# Altium schematic sheet 04_NOTECARD-CONNECTION.SchDoc
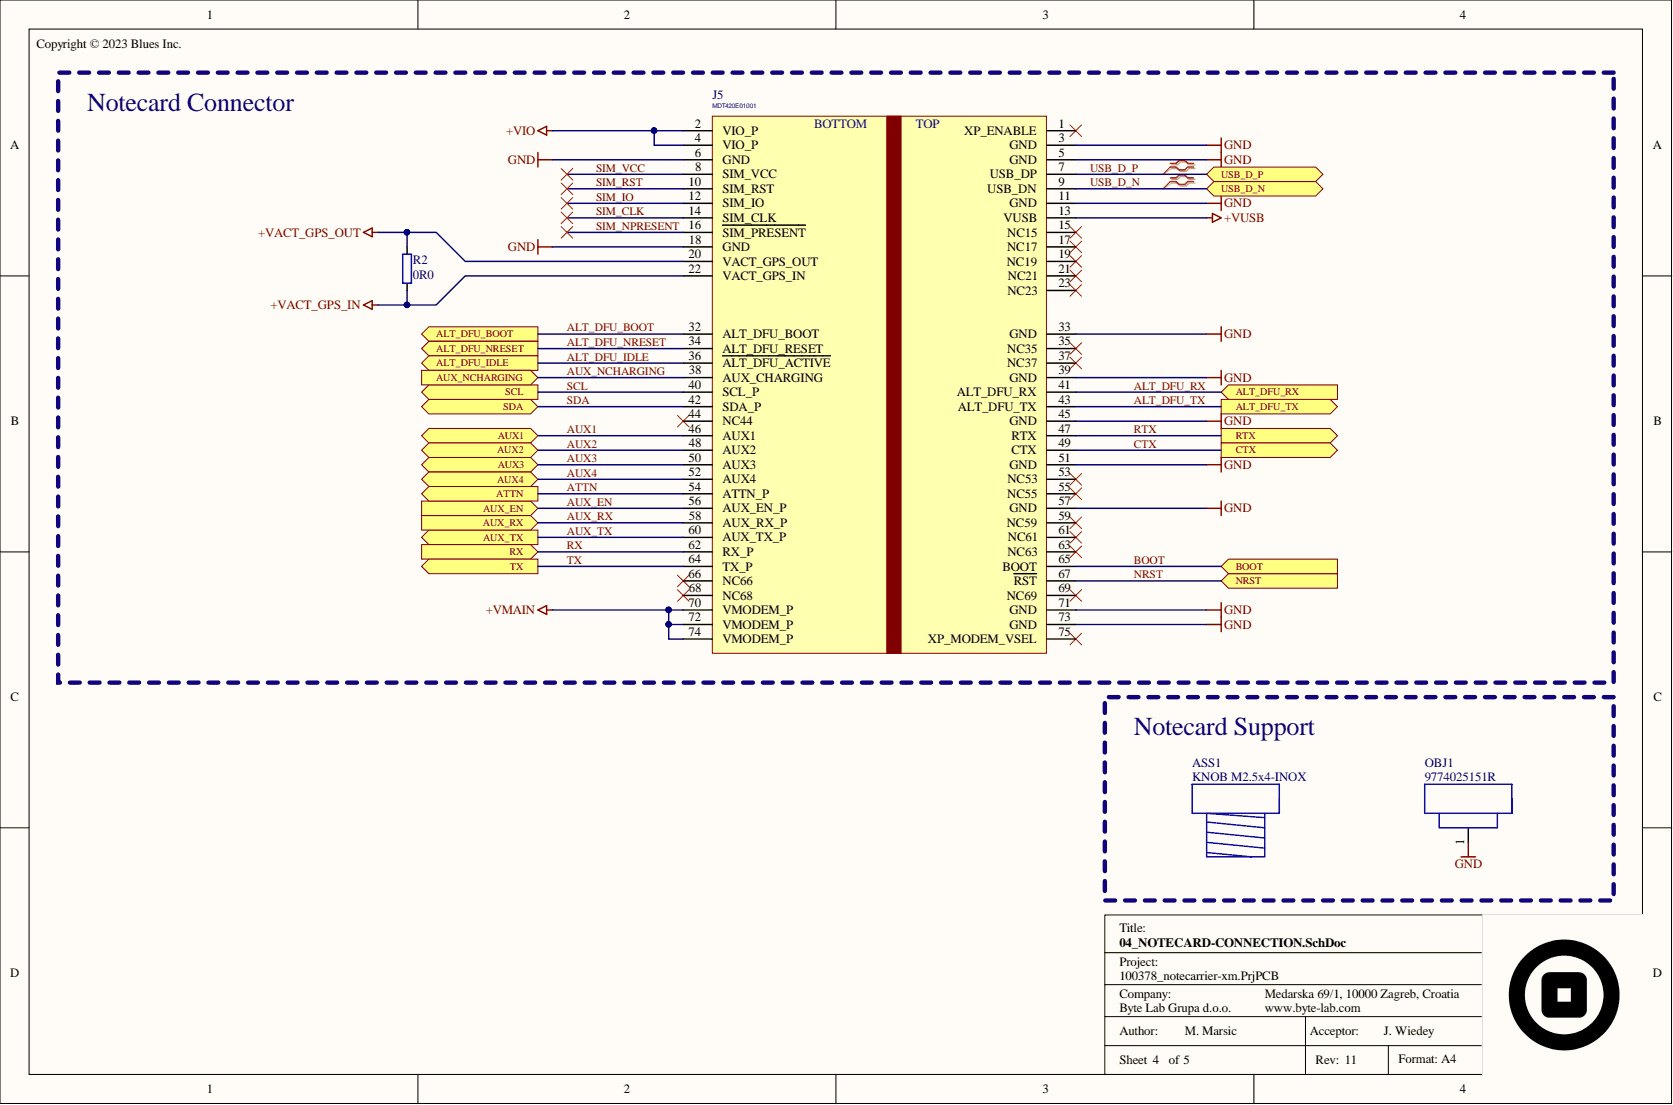
[diagram p0: 04_NOTECARD-CONNECTION.SchDoc]

## Parts
ASS1  KNOB M2.5x4-INOX  (mechanical)
J5  MDT420E01001  [J-75-0050-MOS-M2]
OBJ1  9774025151R  [DIST-WASMSIM0250]
R2  0R0  [R-0603]

## Ports
ALT_DFU_BOOT, ALT_DFU_IDLE, ALT_DFU_NRESET, ALT_DFU_RX, ALT_DFU_TX, ATTN, AUX1, AUX2, AUX3, AUX4, AUX_EN, AUX_NCHARGING, AUX_RX, AUX_TX, BOOT, CTX, NRST, RTX, RX, SCL, SDA, TX, USB_D_N, USB_D_P

## Nets
+VACT_GPS_IN: J5.22(VACT_GPS_IN) R2.1
+VACT_GPS_OUT: J5.20(VACT_GPS_OUT) R2.2
+VIO: J5.2(VIO_P) J5.4(VIO_P)
+VMAIN: J5.70(VMODEM_P) J5.72(VMODEM_P) J5.74(VMODEM_P)
+VUSB: J5.13(VUSB)
GND: J5.3(GND) J5.5(GND) J5.6(GND) J5.11(GND) J5.18(GND) J5.33(GND) J5.39(GND) J5.45(GND) J5.51(GND) J5.57(GND) J5.71(GND) J5.73(GND) OBJ1.1
ALT_DFU_BOOT: J5.32(ALT_DFU_BOOT) ; port ALT_DFU_BOOT
ALT_DFU_IDLE: J5.36(ALT_DFU_ACTIVE) ; port ALT_DFU_IDLE
ALT_DFU_NRESET: J5.34(ALT_DFU_RESET) ; port ALT_DFU_NRESET
ALT_DFU_RX: J5.41(ALT_DFU_RX) ; port ALT_DFU_RX
ALT_DFU_TX: J5.43(ALT_DFU_TX) ; port ALT_DFU_TX
ATTN: J5.54(ATTN_P) ; port ATTN
AUX1: J5.46(AUX1) ; port AUX1
AUX2: J5.48(AUX2) ; port AUX2
AUX3: J5.50(AUX3) ; port AUX3
AUX4: J5.52(AUX4) ; port AUX4
AUX_EN: J5.56(AUX_EN_P) ; port AUX_EN
AUX_NCHARGING: J5.38(AUX_CHARGING) ; port AUX_NCHARGING
AUX_RX: J5.58(AUX_RX_P) ; port AUX_RX
AUX_TX: J5.60(AUX_TX_P) ; port AUX_TX
BOOT: J5.65(BOOT) ; port BOOT
CTX: J5.49(CTX) ; port CTX
NRST: J5.67(RST) ; port NRST
RTX: J5.47(RTX) ; port RTX
RX: J5.62(RX_P) ; port RX
SCL: J5.40(SCL_P) ; port SCL
SDA: J5.42(SDA_P) ; port SDA
SIM_CLK: J5.14(SIM_CLK)
SIM_IO: J5.12(SIM_IO)
SIM_NPRESENT: J5.16(SIM_PRESENT)
SIM_RST: J5.10(SIM_RST)
SIM_VCC: J5.8(SIM_VCC)
TX: J5.64(TX_P) ; port TX
USB_D_N: J5.9(USB_DN) ; port USB_D_N
USB_D_P: J5.7(USB_DP) ; port USB_D_P

Not connected: J5 1(XP_ENABLE) 15(NC15) 17(NC17) 19(NC19) 21(NC21) 23(NC23) 35(NC35) 37(NC37) 44(NC44) 53(NC53) 55(NC55) 59(NC59) 61(NC61) 63(NC63) 66(NC66) 68(NC68) 69(NC69) 75(XP_MODEM_VSEL)

## Library data of the parts
ASS1 = KNOB - M2.5x4-INOX: VITE TCEI 2.5X4 ESAGONO INCASSATO A2 INOX; BL Part Number: 6000-168; Manufacturer: KRAFTBERG; Manufacturer Part Number: M2.5X4/D7985-A2; Drawn_By: Blues Wireless; Library Name: n21-p2.SchLib; Supplier 1: TME; Supplier Part Number 1: M2.5X4/D7985-A2
J5 = AMPHENOL-75 - M-2 KEY E_13: CONN. M.2 75P M/90° P=0.5 MDT420E01001 AMPHENOL SMT; BL Part Number: 2007-460; Manufacturer: Amphenol ICC (FCI); Manufacturer Part Number: MDT420E03001; Drawn_By: Blues Wireless; Component Kind: Standard; Library Name: n21-p2.SchLib; Supplier 1: Digi-Key; Supplier Part Number 1: MDT420E03001CT-ND
OBJ1 = DISTANZIALE - M2.5x0250: DISTANZ. CIL. METALLO 5.1X2.5 9774025151R W.E SMT; BL Part Number: 6020-113; Manufacturer: Würth Elektronik; Drawn_By: Blues Wieless; Component Kind: Standard; Library Name: n21-p2.SchLib; Manufacturer Part Number: 9774025151R; Supplier 1: Digi-Key; Supplier Part Number 1: 732-7078-1-ND
R2 = R0603-0R0: Resistor 0R0 0603; BL Part Number: 2000-723; Checked_By: Puskar; Drawn_By: Dodig; Component Kind: Standard; DateModified: 07-12-2013; Library Name: BL_Passive.SchLib; Manufacturer: N/A; Manufacturer Part Number: N/A; Supplier 1: Digi-Key; Supplier Part Number 1: 311-0.0GRCT-ND
